# Altium schematic sheet output_module.SchDoc
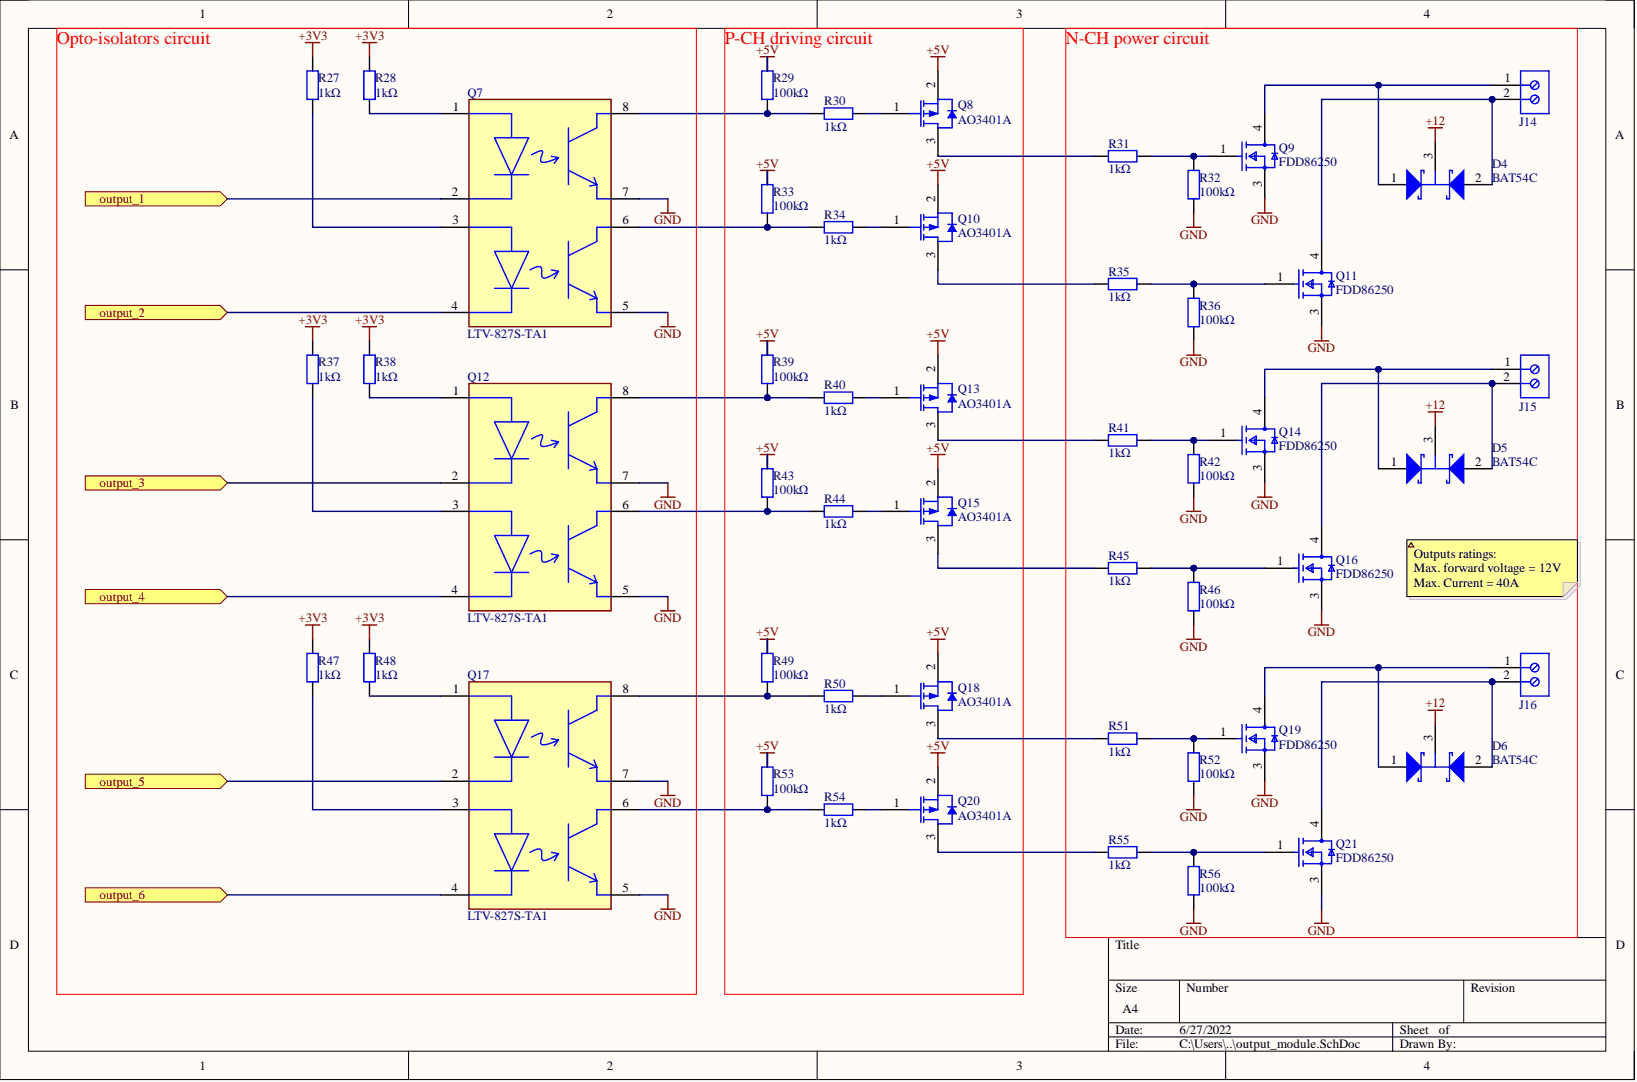
[diagram p0: output_module.SchDoc]

## Parts
D4  BAT54C  [SOT-23]
D5  BAT54C  [SOT-23]
D6  BAT54C  [SOT-23]
J14  282836-2  [TECO-282836-2_V]  (value not drawn)
J15  282836-2  [TECO-282836-2_V]  (value not drawn)
J16  282836-2  [TECO-282836-2_V]  (value not drawn)
Q7  LTV-827S-TA1  [FP-LTV-827S-TA1-MFG]
Q8  AO3401A  [AO-SOT-23-3L_V]
Q9  FDD86250  [FP-369AS-MFG]
Q10  AO3401A  [AO-SOT-23-3L_V]
Q11  FDD86250  [FP-369AS-MFG]
Q12  LTV-827S-TA1  [FP-LTV-827S-TA1-MFG]
Q13  AO3401A  [AO-SOT-23-3L_V]
Q14  FDD86250  [FP-369AS-MFG]
Q15  AO3401A  [AO-SOT-23-3L_V]
Q16  FDD86250  [FP-369AS-MFG]
Q17  LTV-827S-TA1  [FP-LTV-827S-TA1-MFG]
Q18  AO3401A  [AO-SOT-23-3L_V]
Q19  FDD86250  [FP-369AS-MFG]
Q20  AO3401A  [AO-SOT-23-3L_V]
Q21  FDD86250  [FP-369AS-MFG]
R27  1kΩ  [RESC2013X50X30ML20T20]
R28  1kΩ  [RESC2013X50X30ML20T20]
R29  100kΩ  [RESC2013X50X30ML20T20]
R30  1kΩ  [RESC2013X50X30ML20T20]
R31  1kΩ  [RESC2013X50X30ML20T20]
R32  100kΩ  [RESC2013X50X30ML20T20]
R33  100kΩ  [RESC2013X50X30ML20T20]
R34  1kΩ  [RESC2013X50X30ML20T20]
R35  1kΩ  [RESC2013X50X30ML20T20]
R36  100kΩ  [RESC2013X50X30ML20T20]
R37  1kΩ  [RESC2013X50X30ML20T20]
R38  1kΩ  [RESC2013X50X30ML20T20]
R39  100kΩ  [RESC2013X50X30ML20T20]
R40  1kΩ  [RESC2013X50X30ML20T20]
R41  1kΩ  [RESC2013X50X30ML20T20]
R42  100kΩ  [RESC2013X50X30ML20T20]
R43  100kΩ  [RESC2013X50X30ML20T20]
R44  1kΩ  [RESC2013X50X30ML20T20]
R45  1kΩ  [RESC2013X50X30ML20T20]
R46  100kΩ  [RESC2013X50X30ML20T20]
R47  1kΩ  [RESC2013X50X30ML20T20]
R48  1kΩ  [RESC2013X50X30ML20T20]
R49  100kΩ  [RESC2013X50X30ML20T20]
R50  1kΩ  [RESC2013X50X30ML20T20]
R51  1kΩ  [RESC2013X50X30ML20T20]
R52  100kΩ  [RESC2013X50X30ML20T20]
R53  100kΩ  [RESC2013X50X30ML20T20]
R54  1kΩ  [RESC2013X50X30ML20T20]
R55  1kΩ  [RESC2013X50X30ML20T20]
R56  100kΩ  [RESC2013X50X30ML20T20]

## Ports
output_1, output_2, output_3, output_4, output_5, output_6

## Nets
+3V3: R27.1 R28.1 R37.1 R38.1 R47.1 R48.1
+5V: Q8.2 Q10.2 Q13.2 Q15.2 Q18.2 Q20.2 R29.1 R33.1 R39.1 R43.1 R49.1 R53.1
+12: D4.3 D5.3 D6.3
GND: Q7.5 Q7.7 Q9.3 Q11.3 Q12.5 Q12.7 Q14.3 Q16.3 Q17.5 Q17.7 Q19.3 Q21.3 R32.1 R36.1 R42.1 R46.1 R52.1 R56.1
output_1: Q7.2 ; port output_1
output_2: Q7.4 ; port output_2
output_3: Q12.2 ; port output_3
output_4: Q12.4 ; port output_4
output_5: Q17.2 ; port output_5
output_6: Q17.4 ; port output_6
NetD4_1: D4.1 J14.1 Q9.4
NetD4_2: D4.2 J14.2 Q11.4
NetD5_1: D5.1 J15.1 Q14.4
NetD5_2: D5.2 J15.2 Q16.4
NetD6_1: D6.1 J16.1 Q19.4
NetD6_2: D6.2 J16.2 Q21.4
NetQ7_1: Q7.1 R28.2
NetQ7_3: Q7.3 R27.2
NetQ7_6: Q7.6 R33.2 R34.1
NetQ7_8: Q7.8 R29.2 R30.1
NetQ8_1: Q8.1 R30.2
NetQ8_3: Q8.3 R31.1
NetQ9_1: Q9.1 R31.2 R32.2
NetQ10_1: Q10.1 R34.2
NetQ10_3: Q10.3 R35.1
NetQ11_1: Q11.1 R35.2 R36.2
NetQ12_1: Q12.1 R38.2
NetQ12_3: Q12.3 R37.2
NetQ12_6: Q12.6 R43.2 R44.1
NetQ12_8: Q12.8 R39.2 R40.1
NetQ13_1: Q13.1 R40.2
NetQ13_3: Q13.3 R41.1
NetQ14_1: Q14.1 R41.2 R42.2
NetQ15_1: Q15.1 R44.2
NetQ15_3: Q15.3 R45.1
NetQ16_1: Q16.1 R45.2 R46.2
NetQ17_1: Q17.1 R48.2
NetQ17_3: Q17.3 R47.2
NetQ17_6: Q17.6 R53.2 R54.1
NetQ17_8: Q17.8 R49.2 R50.1
NetQ18_1: Q18.1 R50.2
NetQ18_3: Q18.3 R51.1
NetQ19_1: Q19.1 R51.2 R52.2
NetQ20_1: Q20.1 R54.2
NetQ20_3: Q20.3 R55.1
NetQ21_1: Q21.1 R55.2 R56.2

## Library data of the parts
D4-D6 = DIODE_BAT54C: Schottky Barrier Diode, 30 V, 3-Pin SOT23, RoHS, Tape and Reel; Manufacturer: NXP Semiconductors; Common Connection: Cathode; Current Ifsm: 600mA; Forward Current If(AV): 200mA; Forward Voltage: 800mV; Junction Temperature Tj Max: 125degC; No. of Pins: 3; Package Version: Feb-16; Pin Configuration: 1A1,2A2,3comK; Reel Quantity: 3000; Repetitive Reverse Voltage Vrrm Max: 30V; Reverse Recovery Time trr Max: 5ns; SMD Marking: L43/*W1; SVHC: NoSVHC(19-Dec-2012); Component Kind: Standard; Datasheet URL: https://datasheet.ciiva.com/33723/1668625-33723936.pdf; Datasheet Version: Rev. 5 , 10/2012; Diode Case Style: SOT-23; Diode Type: Schottky; Forward Surge Current Ifsm Max: 600mA; Forward Voltage VF Max: 800mV; Library Name: integrated_library.IntLib; Manufacturer URL: http://www.nxp.com/; Operating Temperature Max: 150degC; Operating Temperature Min: -65degC; Operating Temperature Range: -65degCto+150degC; Package / Case: SOT-23; Package Description: 3-Pin Small Outline Transistor, Body 1.3 x 2.9 mm, Pitch 0.95 mm; Package Reference: SOT23-3; Package URL: http://www.nxp.com/documents/outline_drawing/SOT23.pdf; Reverse Recovery Time trr Typ: 5ns; Tape Width: 8mm; Termination Type: SMD
J14-J16 = CMP-1684-00017-1: Female Header, Pitch 5 mm, 1 x 2 Position, Height 10 mm, Tail Length 3.5 mm, Rohs, Bulk; Manufacturer: TE Connectivity; 3D Model: 282836-2.pdf; Clamp Material - Plating: Brass-NickelPlated; Current: 13.5A; Dynamic Catalog: BuchananSeries; Featured Product: EurostyleTerminalBlockConnectors; Mating Orientation: HorizontalwithBoard; Number of Levels: 1; Other Names: 5ULV-02B  A98076; Pitch: 0.197"(5.00mm); Positions Per Level: 2; Product Training Modules: Wire-to-BoardEurostyleTerminalBlocks; Screw Thread: M3; Torque - Screw: 0.68Nm(6Lb-In); Voltage: 300V; Wire Gauge: 16-30AWG; Wire Termination: Screw-RisingCageClamp; Category: Connectors,Interconnects; Color: Green; Component Kind: Standard; Contact Material - Plating: Brass-TinPlated; Datasheet URL: http://www.te.com.cn/commerce/DocumentDelivery/DDEController?Action=showdoc&DocId=Catalog+Section%7F1308389_EUROSTYLE_TERMINAL_BLOCKS%7F0607%7Fpdf%7FEnglish%7FENG_CS_1308389_EUROSTYLE_TERMINAL_BLOCKS_0607.pdf%7F282836-2; Family: TerminalBlocks-WiretoBoard; Features: Interlocking(Side); Housing Material: Polyamide(PA66),Nylon6/6; Library Name: Altium Content Vault; Manufacturer URL: http://www.te.com; Mounting Type: ThroughHole; Package Description: Female Header, Pitch 5 mm, 1 x 2 Position, Height 10 mm, Tail Length 3.5 mm; Package Reference: THD; Packaging: Bulk; RoHS Information: 282836-2StatementofCompliance; Screw Material - Plating: Brass-NickelPlated; Series: Buchanan; Standard Package: 300
Q7 Q12 Q17 = CMP-22018-000005-1: OPTOISOLATOR 5KV 2CH TRANS 8-SMD; Collector Emitter Saturation Voltage: 200mV; Collector Emitter Voltage (VCEO): 35V; Current Transfer Ratio: 600%; Fall Time: 18000ns; Forward Current: 50mA; Forward Voltage: 1.4V; Max Power Dissipation: 200mW; Number of Channels: 2; Reverse Breakdown Voltage: 6V; Case/Package: SMD/SMT; Component Kind: Standard; Isolation Voltage: 5kV; Lead Free: Lead Free; Library Name: Altium Content Vault; Max Collector Current: 50mA; Max Input Current: 50mA; Max Operating Temperature: 110°C; Max Output Voltage: 35V; Min Operating Temperature: -30°C; Mount: Surface Mount; Number of Circuits: 2; Number of Elements: 2; Number of Pins: 8; Output Current per Channel: 50mA; Output Voltage: 35V; Package Quantity: 1000; Packaging: Tape and Reel; Power Dissipation: 200mW; Radiation Hardening: No; Rise Time: 18000ns
Q8 Q10 Q13 Q15 Q18 Q20 = CMP-2000-05778-1: P-Channel MOSFET, 30 V, 4 A, -55 to 150 degC, 3-Pin SOT-23-3L, RoHS, Tape and Reel; Manufacturer: Alpha and Omega; Channel Mode: Enhancement; Continuous Drain Current: 4A; Drain Efficiency: NotRequired%; Drain-Source On-Res: 0.044ohm; Drain-Source On-Volt: 30V; Frequency (Max): NotRequiredMHz; Gate-Source Voltage (Max): ?12V; Noise Figure: NotRequireddB; Number of  Elements: 1; Output Power (Max): NotRequiredW; Polarity: P; Power Dissipation: 1.4W; Rad Hardened: No; Type: PowerMOSFET; Component Kind: Standard; Datasheet URL: http://www.aosmd.com/res/data_sheets/AO3401A.pdf; Datasheet Version: Rev. 3, 03/2011; Drain Current (Max): 4A; Library Name: Altium Content Vault; Manufacturer URL: http://www.aosmd.com/; Mounting: SurfaceMount; Mounting Technology: SurfaceMount; Operating Temp Range: -55Cto150C; Operating Temperature Classification: Military; Package Description: 3-Pin Small Outline Transistor, Body 2.95 x 1.6 mm, Pitch 0.95 mm; Package Reference: SOT-23-3L; Package Type: SOT-23; Package URL: http://www.aosmd.com/res/packaging_information/SOT-23-3L.pdf; Pin Count: 3; Power Gain: NotRequireddB
Q9 Q11 Q14 Q16 Q19 Q21 = CMP-07173-000037-1: MOSFET N-CH 150V 8A DPAK; Manufacturer: ON Semiconductor; Depletion Mode: False; Drain To Source Resistance: 18.4mΩ; Gate Charge At Vgs: 23nC @ 10V; Gate To Source Voltage: 20V; Input Capacitace At Vds: 1585pF @ 75V; Max Forward Diode Voltage: 1.3V; Max Junction Temp: +150°C; Number Of Channels: 1; Power Dissipation: 3.1W; Pulse Drain Current: 164A; Reverse Recovery Charge: 104nC; Reverse Recovery Time: 71ns; Rthja Max: 40°C/W; Threshold Vgs Max: 4V; Threshold Vgs Min: 2V; Transconductance: 28S; Turn Off Delay Time: 20ns; Turn On Delay Time: 11.2ns; Typ Forward Diode Voltage: 0.78V; Automotive: No; Category: Trans; Component Kind: Standard; ComponentLink2Description: Datasheet; ComponentLink2URL: https://www.onsemi.com/pub/Collateral/FDD86250-D.pdf; Continuous Drain Current: 8A; Device Class L1: Discrete Semiconductors; Device Class L2: Transistors; Device Class L3: MOSFETs; Digikey Description: MOSFET N-CH 150V 8A DPAK; Drain To Source Breakdown Voltage: 150V; Drain To Source Voltage: 150V; Footprint Url: https://www.onsemi.com/pub/Collateral/369AS.PDF; Height: 2.517mm; Lead Free: Yes; Library Name: Altium Content Vault; Manufacturer Part Number: FDD86250; Mouser Description: Shielded Gate PowerTrench® MOSFET, N-Channel, 150 V, 51 A, 22 mO; Number Of N Channels: 1; Octopart: https://octopart.com/fdd86250-on+semiconductor-84325855; Package: 369AS; RoHS: TRUE; Temperature: -55°C to +150°C; Temperature Range High: +150°C; Temperature Range Low: -55°C
R27-R56 = Res_0805: Resistor; Component Kind: Standard; Library Name: PCB_Project.SchLib; Signal Integrity: Res; Simulation: RESISTOR
